# Altium schematic sheet IMU.SchDoc
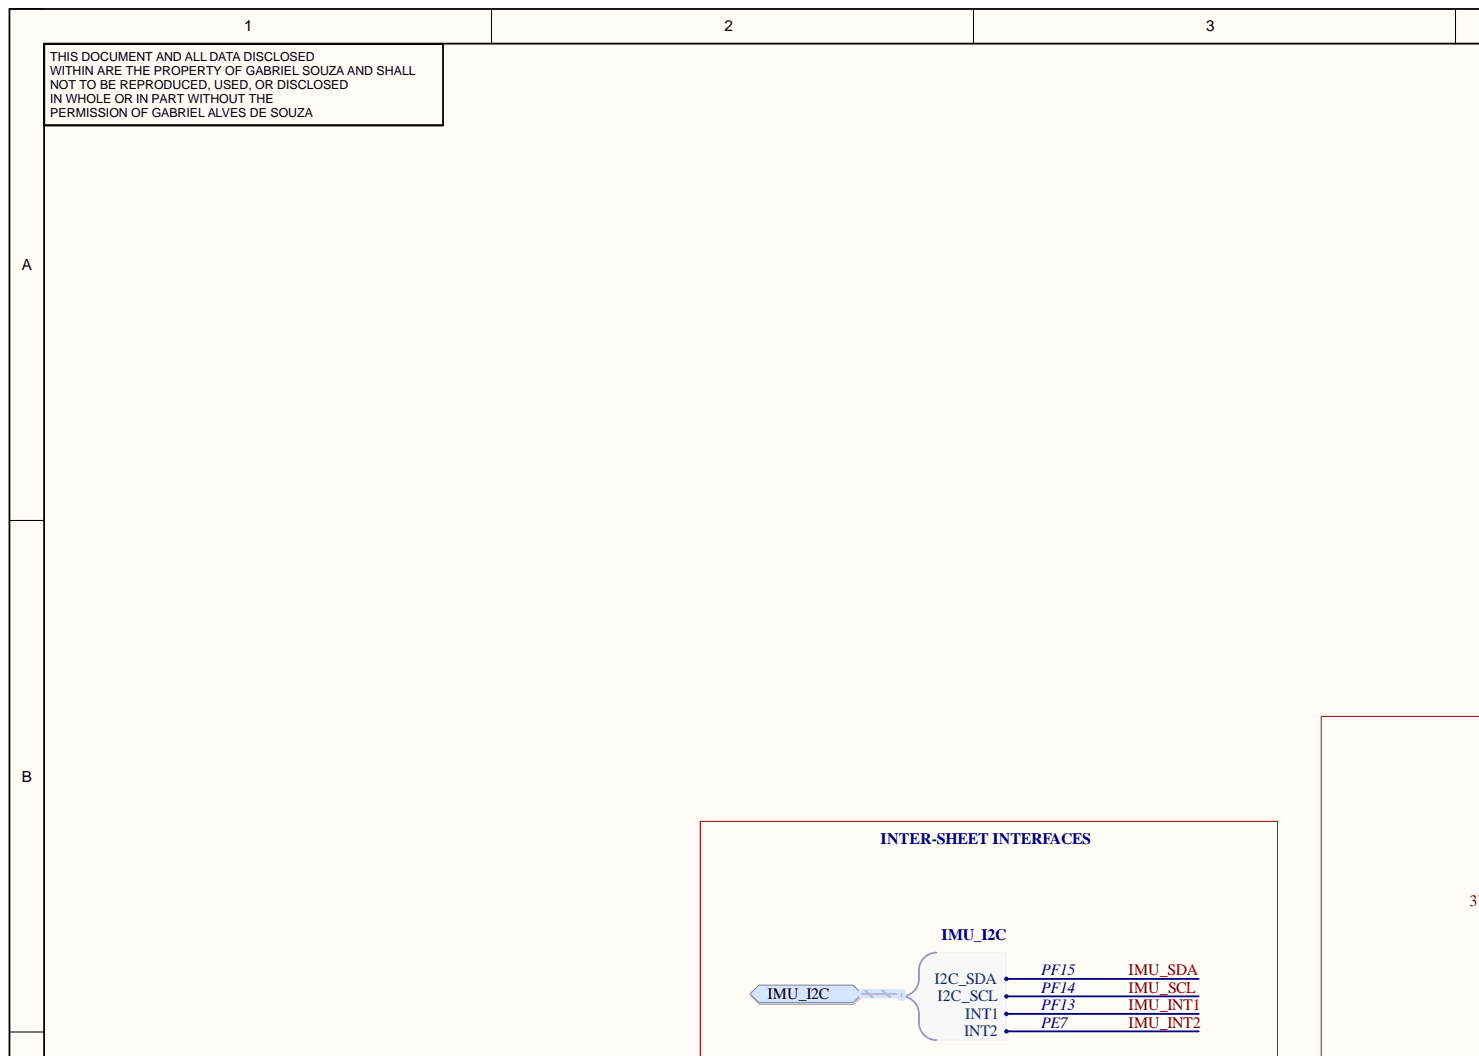
[diagram p0: IMU.SchDoc, top left]
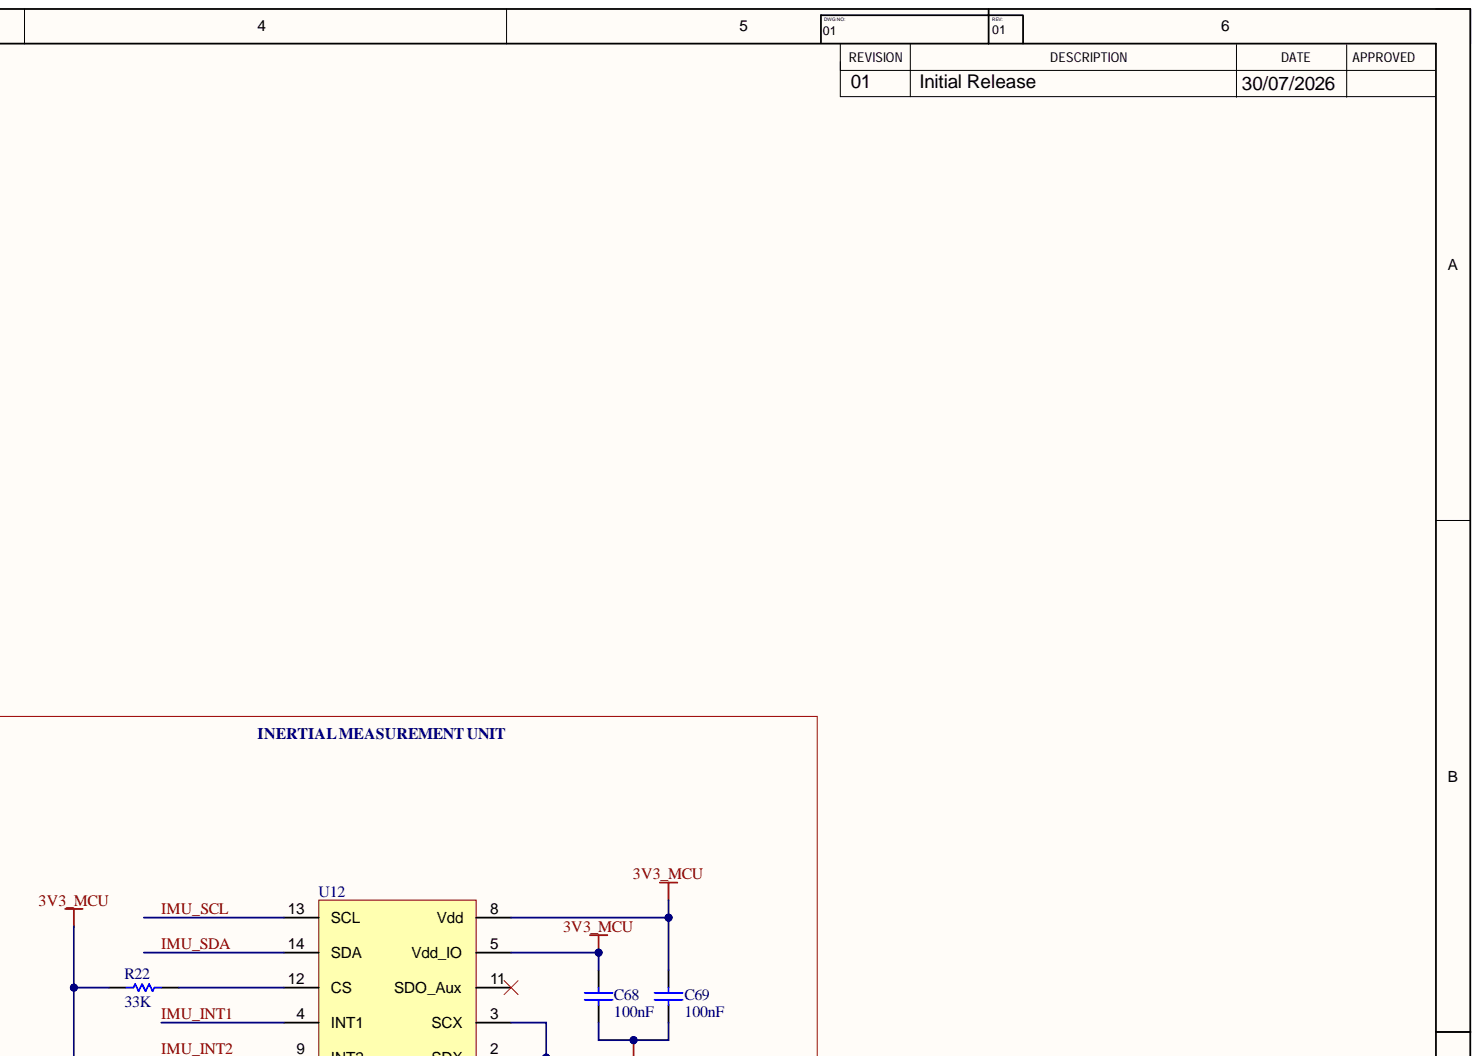
[diagram p1: IMU.SchDoc, top right]
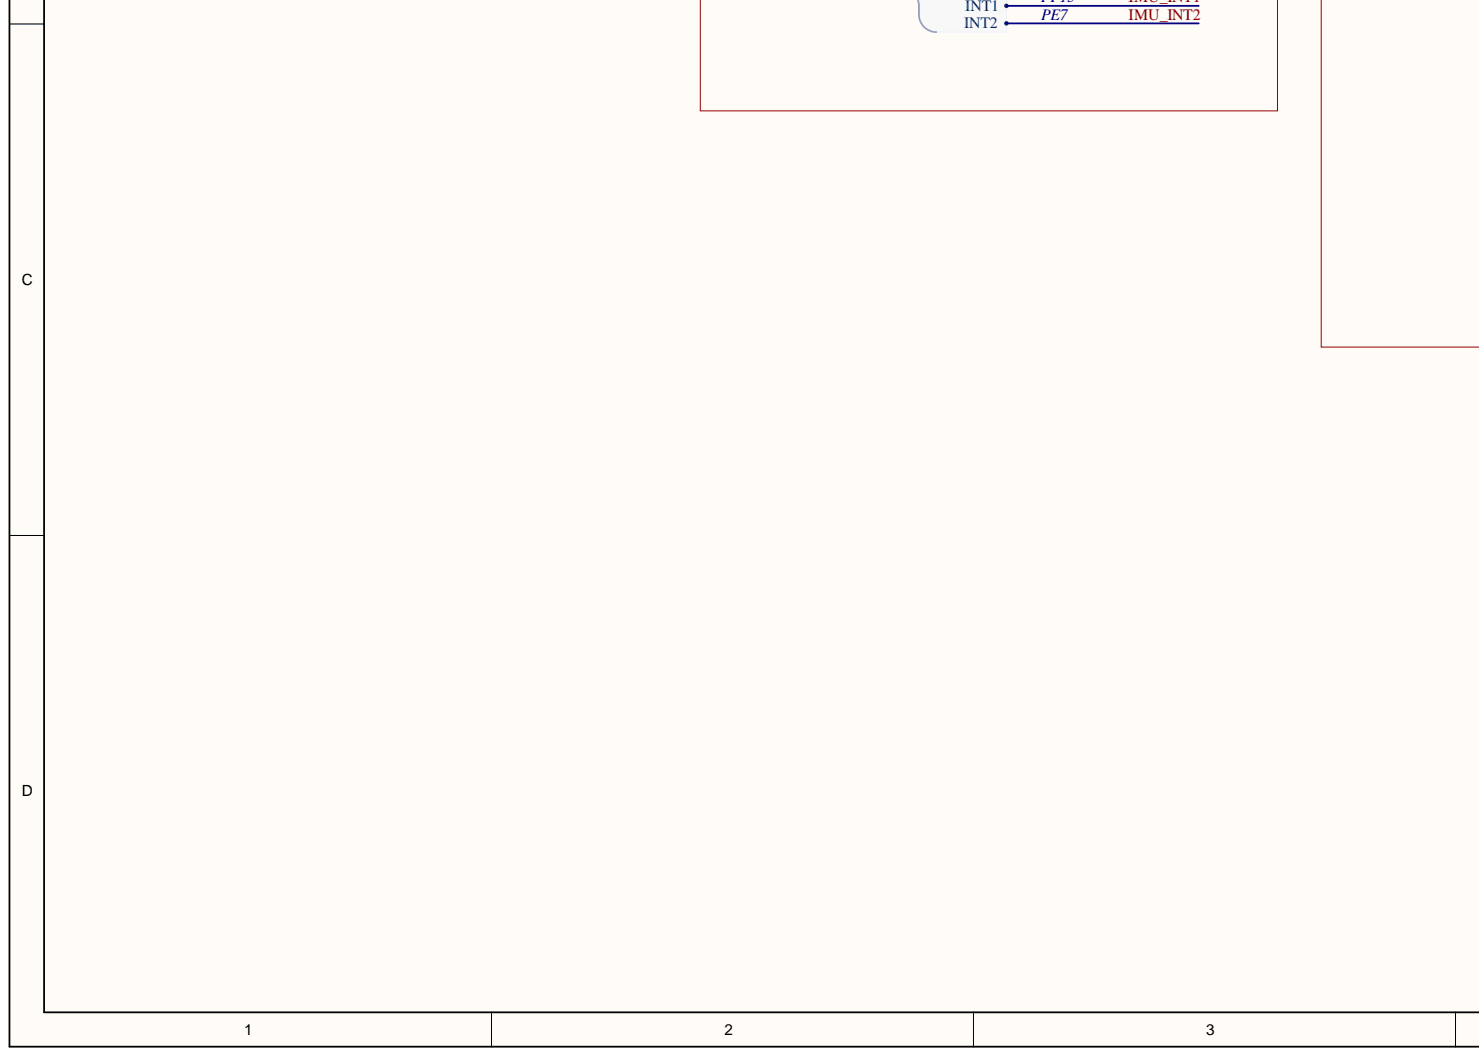
[diagram p2: IMU.SchDoc, bottom left]
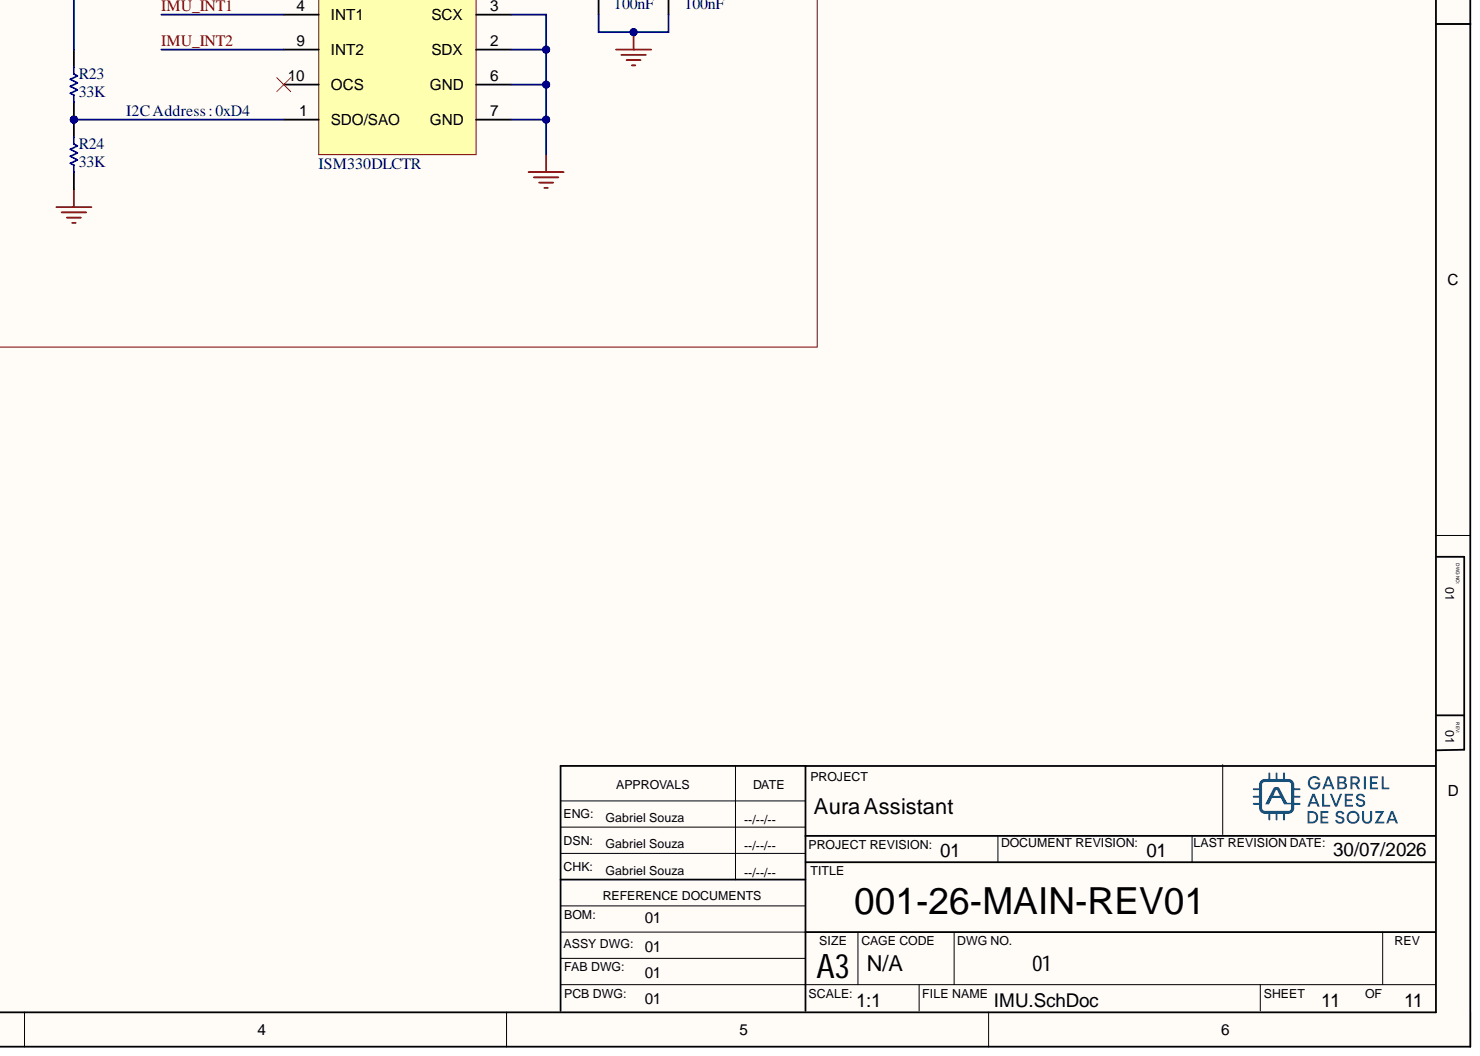
[diagram p3: IMU.SchDoc, bottom right]

## Parts
C68  value 100nF  [C_0402_IPC_N]  (hidden comment: Capacitor)
C69  value 100nF  [C_0402_IPC_N]  (hidden comment: Capacitor)
R22  value 33K  [R_0402_IPC_N]  (hidden comment: Resistor)
R23  value 33K  [R_0402_IPC_N]  (hidden comment: Resistor)
R24  value 33K  [R_0402_IPC_N]  (hidden comment: Resistor)
U12  ISM330DLCTR  [ICC_LGA14_3X2P5_P050]

## Ports
IMU_I2C, IMU_I2C.I2C_SCL, IMU_I2C.I2C_SDA, IMU_I2C.INT1, IMU_I2C.INT2

## Nets
3V3_MCU: C68.2 C69.2 R22.1 R23.1 U12.5(Vdd_IO) U12.8(Vdd)
GND: C68.1 C69.1 R24.2 U12.2(SDX) U12.3(SCX) U12.6(GND) U12.7(GND)
IMU_INT1: U12.4(INT1) ; port IMU_I2C
IMU_INT2: U12.9(INT2) ; port IMU_I2C
IMU_SCL: U12.13(SCL) ; port IMU_I2C
IMU_SDA: U12.14(SDA) ; port IMU_I2C
NetR22_2: R22.2 U12.12(CS)
NetR23_2: R23.2 R24.1 U12.1(SDO/SAO)

Not connected: U12 10(OCS) 11(SDO_Aux)

## Library data of the parts
C68 C69 = CAP: Capacitor, MLCC, 100nF, 16V, X7R, +/-10%, 0402, T=0,5mm; Manufacturer: TDK; Manufacturer_Part_Number: C1005X7R1C104KT000F; Component Kind: Standard; ComponentLink1Description: Datasheet; ComponentLink1URL: https://www.lcsc.com/datasheet/C338032.pdf?spm=wm.sxq.inf.ggs___wm.fly.bg.6.xh&lcsc_vid=TlIKVlcFRVJWVl1WQVFfBlQDRFgPAVVQRFhaBQIFFgQxVlNeRVRbU1RQQ1dWUTtW; Order_Code_LCSC: C338032; Package: 0402; Library Name: Gabriel_Lib.IntLib
R22-R24 = RES: Resistor, 33K, 0402, 0.1%, 62.5mW; Manufacturer: YAGEO; Manufacturer_Part_Number: RT0402BRD0733KL; Component Kind: Standard; ComponentLink1Description: Datasheet; Order_Code_LCSC: C728559; Package: 0402; Part_Type: Resistor; Library Name: Gabriel_Lib.IntLib
U12 = MCD-01714: iNEMO inertial module: 3D accelerometer and 3D gyroscope, with digital output for industrial applications; Manufacturer: STMICROELECTRONICS; Manufacturer_HQ_COO: EU; Part_Preference: Recommended; Part_Type: IC; Component_Country_Of_Origin: Philippines; Database Table Name: MCD_PARTS$; ID: 3814; ShowFields: YES; Component Kind: Standard; ComponentLink1URL: http://tunmcdsvn.tun.st.com/Component_Documentation_Library/Trunk/IC/STMICROELECTRONICS_ISM330DLCTR; Internal_Part_Number: MCD-01714; Library Name: MCD_PARTS_database.DbLib; Manufacturer_Part_Number: ISM330DLCTR; Order_Code_Digikey: 497-17583-2-ND; Order_Code_Farnell: 2776651; Order_Code_LCSC: C2943126; Package: LGA-14; Status: Checked; Value: ISM330DLCTR
